# Altium schematic sheet Schematic Prints("All Documents",Physical)
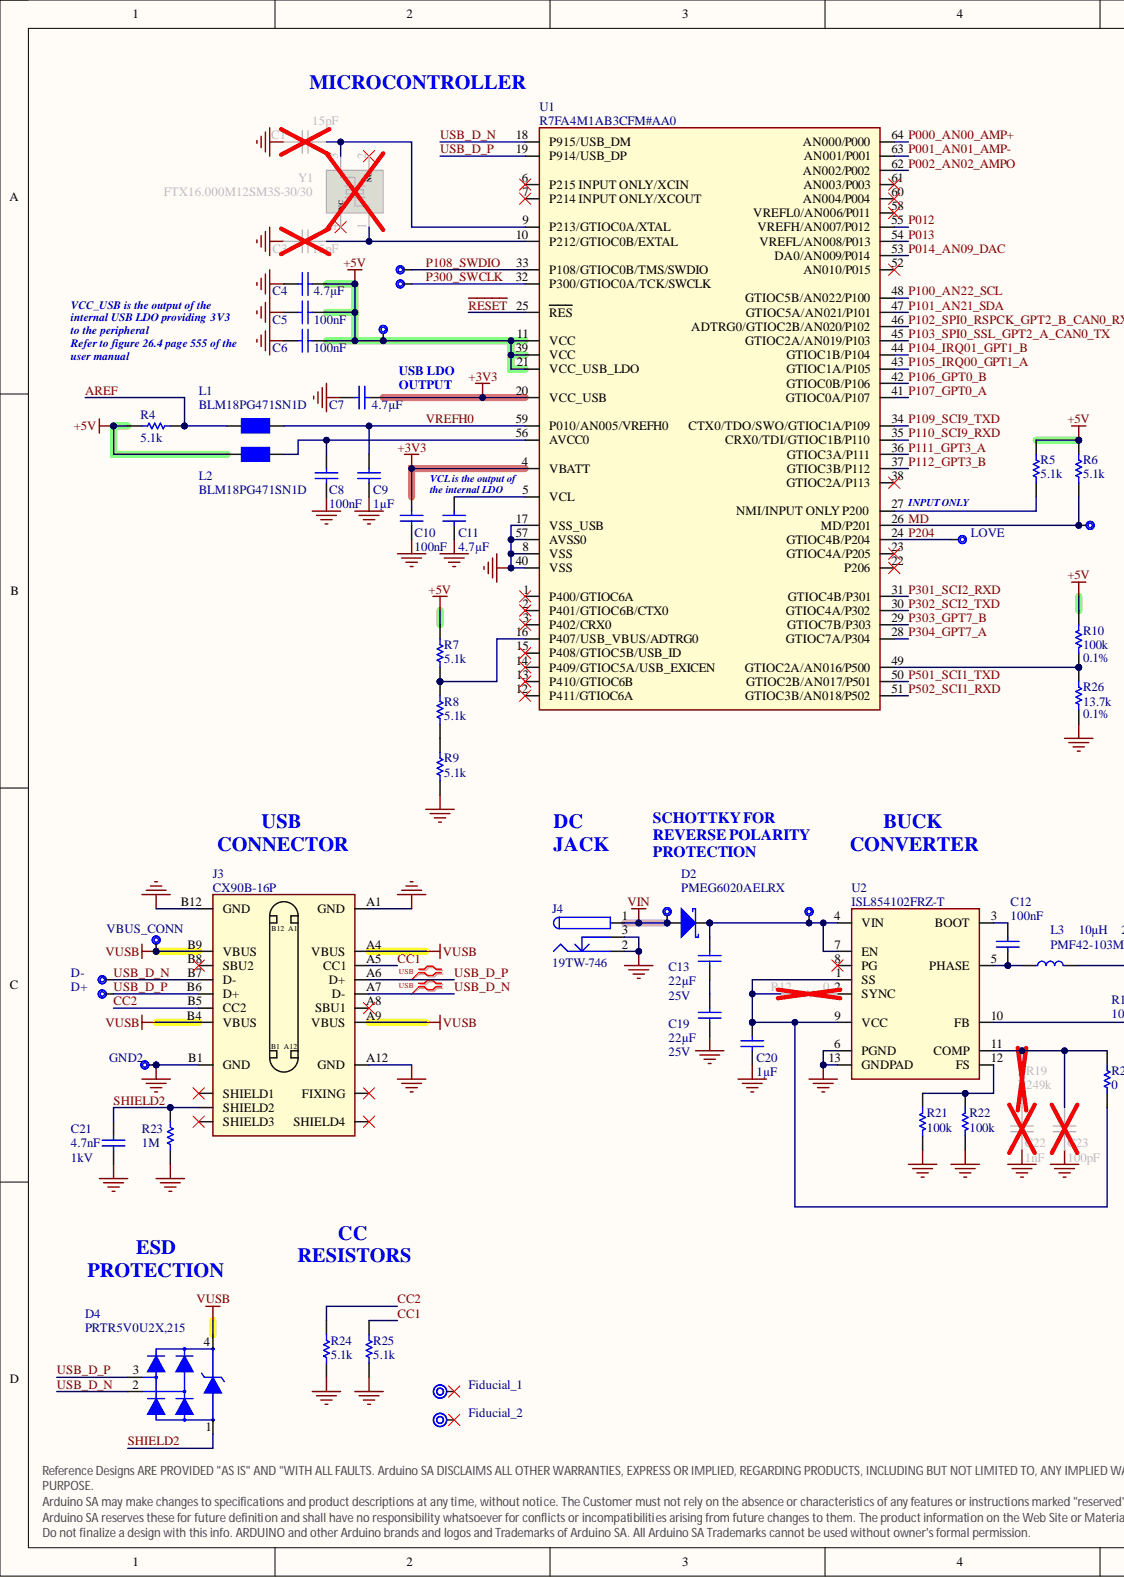
[diagram p0: Schematic Prints("All Documents",Physical), left]
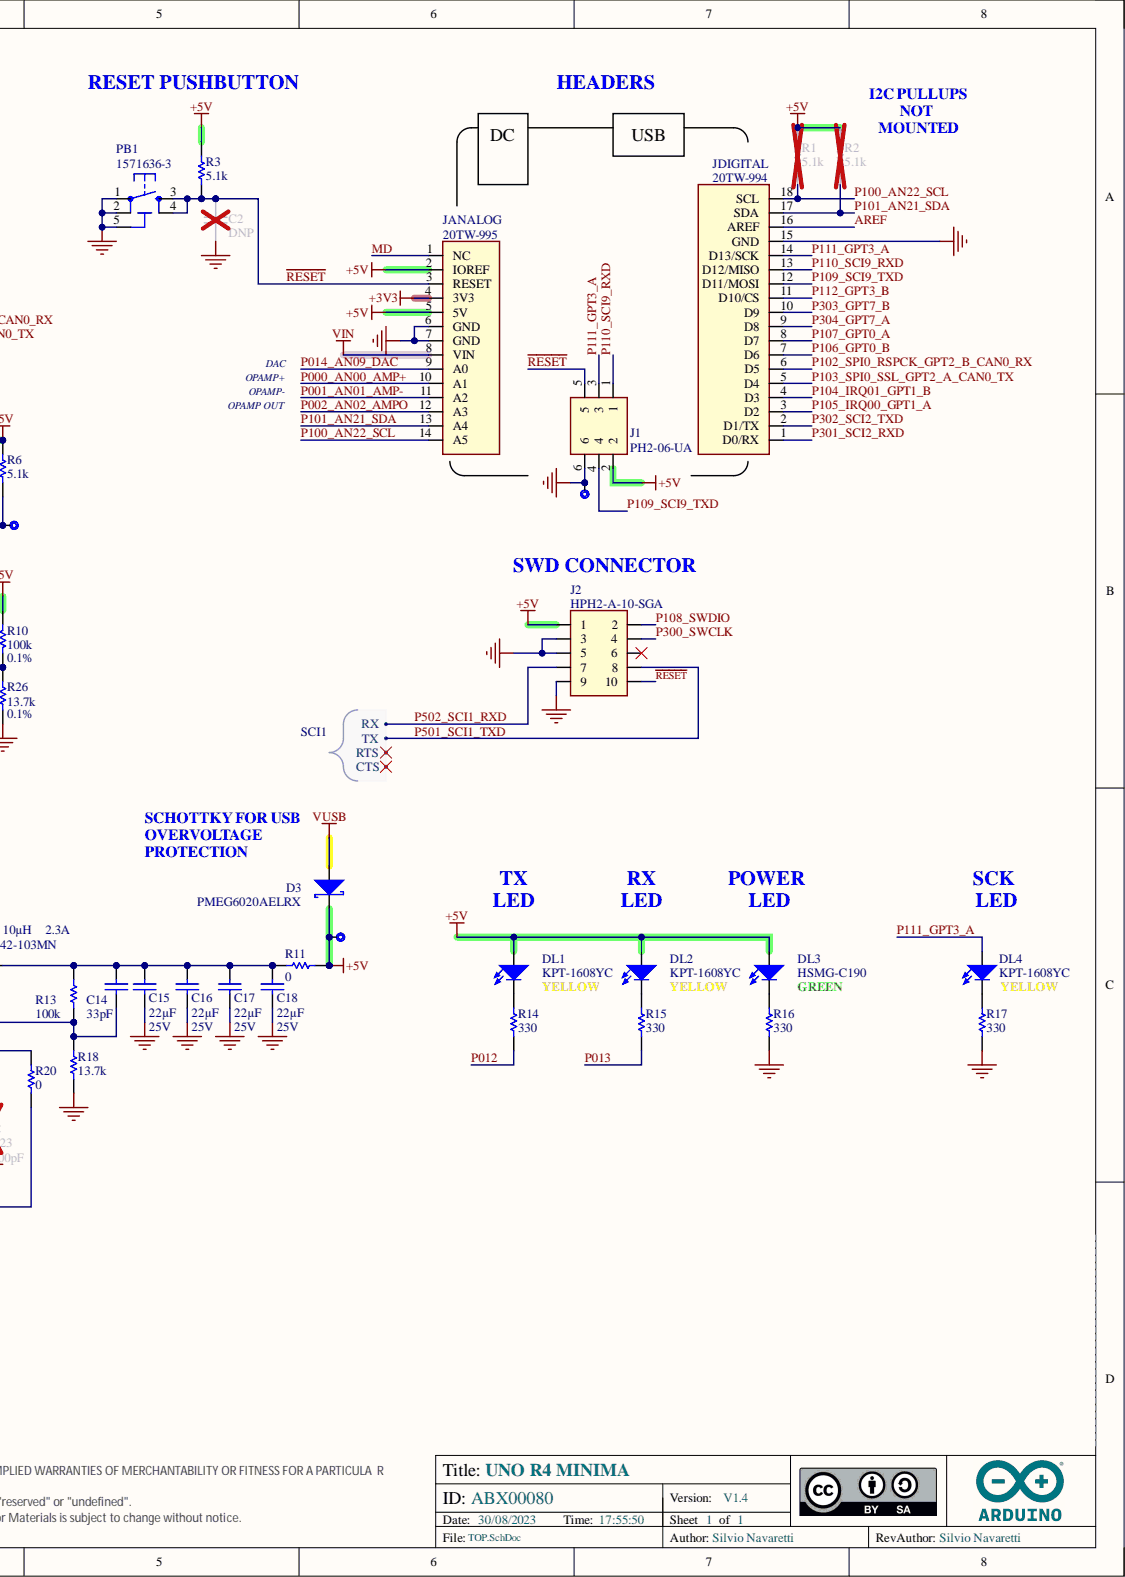
[diagram p1: Schematic Prints("All Documents",Physical), right]

## Parts
1  (no value)
BUCK_IN_TOP1  (no value)
C1  value 15pF
C2  (no value)
C3  value 15pF
C4  value 4.7µF
C5  value 100nF
C6  value 100nF
C7  value 4.7µF
C8  value 100nF
C9  value 1µF
C10  value 100nF
C11  value 4.7µF
C12  value 100nF
C13  value 22µF
C14  value 33pF
C15  value 22µF  Voltage: 25V
C16  value 22µF  Voltage: 25V
C17  value 22µF  Voltage: 25V
C18  value 22µF  Voltage: 25V
C19  value 22µF  Voltage: 25V
C20  value 1µF
C21  value 4.7nF  Voltage: 1kV
C22  value 1nF
C23  value 100pF
D2  Part Number: PMEG6020AELRX
D3  Part Number: PMEG6020AELRX
D4  Part Number: PRTR5V0U2X,215
D+  (no value)
D-  (no value)
DL1  Part Number: KPT-1608YC
DL2  Part Number: KPT-1608YC
DL3  Part Number: HSMG-C190
DL4  Part Number: KPT-1608YC
Fiducial_1  (no value)
Fiducial_2  (no value)
GND1  (no value)
GND2  (no value)
J1  Part Number: PH2-06-UA
J2  Part Number: HPH2-A-10-SGA
J3  Part Number: CX90B-16P
J4  Part Number: 19TW-746
JANALOG  Part Number: 20TW-995
JDIGITAL  Part Number: 20TW-994
L1  Part Number: BLM18PG471SN1D
L2  Part Number: BLM18PG471SN1D
L3  Inductance: 10µH  Part Number: PMF42-103MN
LOVE  (no value)
MD  (no value)
PB1  Part Number: 1571636-3
R1  value 5.1k
R2  value 5.1k
R3  value 5.1k
R4  value 5.1k
R5  value 5.1k
R6  value 5.1k
R7  value 5.1k
R8  value 5.1k
R9  value 5.1k
R10  value 100k  Tolerance: 0.1%
R11  value 0
R12  value 0
R13  value 100k
R14  value 330
R15  value 330
R16  value 330
R17  value 330
R18  value 13.7k
R19  value 249k
R20  value 0
R21  value 100k
R22  value 100k
R23  value 1M
R24  value 5.1k
R25  value 5.1k
R26  value 13.7k  Tolerance: 0.1%
SWCLK1  (no value)
SWDIO1  (no value)
U1  Part Number: R7FA4M1AB3CFM#AA0
U2  Part Number: ISL854102FRZ-T
VBUS_CONN  (no value)
VCC1  (no value)
VIN_TOP1  (no value)
Y1  Part Number: FTX16.000M12SM3S-30/30

## Nets
+3V3: C7.2 C10.2 JANALOG.4(3V3) U1.4(VBATT) U1.20(VCC_USB)
+5V: 1.X C4.2 C5.2 C6.2 D3.C DL1.A DL2.A DL3.A J1.2 J2.1 JANALOG.2(IOREF) JANALOG.5(5V) L2.1 R1.2 R2.2 R3.2 R4.2 R5.1 R6.1 R7.2 R10.2 R11.2 U1.11(VCC) U1.21(VCC_USB_LDO) U1.39(VCC) VCC1.X
GND: C1.2 C2.2 C3.2 C4.1 C5.1 C6.1 C7.1 C8.1 C9.2 C10.1 C11.1 C15.1 C16.1 C17.1 C18.1 C19.1 C20.2 C21.1 C22.1 C23.1 GND1.X GND2.X J1.6 J2.3 J2.5 J2.9 J3.A1(GND) J3.A12(GND) J3.B1(GND) J3.B12(GND) J4.2 J4.3 JANALOG.6(GND) JANALOG.7(GND) JDIGITAL.15(GND) PB1.1 PB1.2 PB1.5 R9.1 R16.1 R17.1 R18.1 R21.1 R22.1 R23.1 R24.1 R25.1 R26.1 U1.8(VSS) U1.17(VSS_USB) U1.40(VSS) U1.57(AVSS0) U2.6(PGND) U2.13(GNDPAD)
VIN: D2.A J4.1 JANALOG.8(VIN) VIN_TOP1.X
VUSB: D3.A D4.4 J3.A4(VBUS) J3.A9(VBUS) J3.B4(VBUS) J3.B9(VBUS) VBUS_CONN.X
AREF_1: JDIGITAL.16(AREF) L1.1 R4.1  [also labelled AREF]
CC1_1: J3.A5(CC1) R25.2  [also labelled CC1]
CC2_1: J3.B5(CC2) R24.2  [also labelled CC2]
MD_1: JANALOG.1(NC) MD.X R6.2 U1.26(MD/P201)  [also labelled MD]
P000_AN00_AMP+_1: JANALOG.10(A1) U1.64(AN000/P000)  [also labelled P000_AN00_AMP+]
P001_AN01_AMP-_1: JANALOG.11(A2) U1.63(AN001/P001)  [also labelled P001_AN01_AMP-]
P002_AN02_AMPO_1: JANALOG.12(A3) U1.62(AN002/P002)  [also labelled P002_AN02_AMPO]
P012_1: R14.1 U1.55(VREFH/AN007/P012)  [also labelled P012]
P013_1: R15.1 U1.54(VREFL/AN008/P013)  [also labelled P013]
P014_AN09_DAC_1: JANALOG.9(A0) U1.53(DA0/AN009/P014)  [also labelled P014_AN09_DAC]
P100_AN22_SCL_1: JANALOG.14(A5) JDIGITAL.18(SCL) R1.1 U1.48(GTIOC5B/AN022/P100)  [also labelled P100_AN22_SCL]
P101_AN21_SDA_1: JANALOG.13(A4) JDIGITAL.17(SDA) R2.1 U1.47(GTIOC5A/AN021/P101)  [also labelled P101_AN21_SDA]
P102_SPI0_RSPCK_GPT2_B_CAN0_RX_1: JDIGITAL.6(D5) U1.46(ADTRG0/GTIOC2B/AN020/P102)  [also labelled P102_SPI0_RSPCK_GPT2_B_CAN0_RX]
P103_SPI0_SSL_GPT2_A_CAN0_TX_1: JDIGITAL.5(D4) U1.45(GTIOC2A/AN019/P103)  [also labelled P103_SPI0_SSL_GPT2_A_CAN0_TX]
P104_IRQ01_GPT1_B_1: JDIGITAL.4(D3) U1.44(GTIOC1B/P104)  [also labelled P104_IRQ01_GPT1_B]
P105_IRQ00_GPT1_A_1: JDIGITAL.3(D2) U1.43(GTIOC1A/P105)  [also labelled P105_IRQ00_GPT1_A]
P106_GPT0_B_1: JDIGITAL.7(D6) U1.42(GTIOC0B/P106)  [also labelled P106_GPT0_B]
P107_GPT0_A_1: JDIGITAL.8(D7) U1.41(GTIOC0A/P107)  [also labelled P107_GPT0_A]
P108_SWDIO_1: J2.2 SWDIO1.X U1.33(P108/GTIOC0B/TMS/SWDIO)  [also labelled P108_SWDIO]
P109_SCI9_TXD_1: J1.4 JDIGITAL.12(D11/MOSI) U1.34(CTX0/TDO/SWO/GTIOC1A/P109)  [also labelled P109_SCI9_TXD]
P110_SCI9_RXD_1: J1.1 JDIGITAL.13(D12/MISO) U1.35(CRX0/TDI/GTIOC1B/P110)  [also labelled P110_SCI9_RXD]
P111_GPT3_A_1: DL4.A J1.3 JDIGITAL.14(D13/SCK) U1.36(GTIOC3A/P111)  [also labelled P111_GPT3_A]
P112_GPT3_B_1: JDIGITAL.11(D10/CS) U1.37(GTIOC3B/P112)  [also labelled P112_GPT3_B]
P204_1: LOVE.X U1.24(GTIOC4B/P204)  [also labelled P204]
P300_SWCLK_1: J2.4 SWCLK1.X U1.32(P300/GTIOC0A/TCK/SWCLK)  [also labelled P300_SWCLK]
P301_SCI2_RXD_1: JDIGITAL.1(D0/RX) U1.31(GTIOC4B/P301)  [also labelled P301_SCI2_RXD]
P302_SCI2_TXD_1: JDIGITAL.2(D1/TX) U1.30(GTIOC4A/P302)  [also labelled P302_SCI2_TXD]
P303_GPT7_B_1: JDIGITAL.10(D9) U1.29(GTIOC7B/P303)  [also labelled P303_GPT7_B]
P304_GPT7_A_1: JDIGITAL.9(D8) U1.28(GTIOC7A/P304)  [also labelled P304_GPT7_A]
P501_SCI1_TXD_1: J2.8 U1.50(GTIOC2B/AN017/P501)  [also labelled P501_SCI1_TXD]
P502_SCI1_RXD_1: J2.7 U1.51(GTIOC3B/AN018/P502)  [also labelled P502_SCI1_RXD]
~{RESET}_1: C2.1 J1.5 J2.10 JANALOG.3(RESET) PB1.3 PB1.4 R3.1 U1.25(RES)  [also labelled ~{RESET}]
SHIELD2_1: C21.2 D4.1 J3.SHIELD2 R23.2  [also labelled SHIELD2]
USB_D_1_N: D4.2 D-.X J3.A7(D-) J3.B7(D-) U1.18(P915/USB_DM)  [also labelled USB_D_N]
USB_D_1_P: D4.3 D+.X J3.A6(D+) J3.B6(D+) U1.19(P914/USB_DP)  [also labelled USB_D_P]
VREFH0_1: C9.1 L1.2 U1.59(P010/AN005/VREFH0)  [also labelled VREFH0]
NetBUCK_IN_TOP1_X: BUCK_IN_TOP1.X C13.2 D2.C U2.4(VIN) U2.7(EN)
NetC1_1: C1.1 U1.9(P213/GTIOC0A/XTAL) Y1.3
NetC3_1: C3.1 U1.10(P212/GTIOC0B/EXTAL) Y1.1
NetC8_2: C8.2 L2.2 U1.56(AVCC0)
NetC11_2: C11.2 U1.5(VCL)
NetC12_1: C12.1 L3.1 U2.5(PHASE)
NetC12_2: C12.2 U2.3(BOOT)
NetC13_1: C13.1 C19.2
NetC14_1: C14.1 R13.2 R18.2 U2.10(FB)
NetC14_2: C14.2 C15.2 C16.2 C17.2 C18.2 L3.2 R11.1 R13.1
NetC20_1: C20.1 R12.2 R20.2 U2.1(SS) U2.9(VCC)
NetC22_2: C22.2 R19.1
NetC23_2: C23.2 R19.2 R20.1 U2.11(COMP)
NetDL1_C: DL1.C R14.2
NetDL2_C: DL2.C R15.2
NetDL3_C: DL3.C R16.2
NetDL4_C: DL4.C R17.2
NetFiducial_1_1: Fiducial_1.1
NetFiducial_2_1: Fiducial_2.1
NetJ2_6: J2.6
NetJ3_A8: J3.A8(SBU1)
NetJ3_B8: J3.B8(SBU2)
NetJ3_FIXING: J3.FIXING
NetJ3_SHIELD1: J3.SHIELD1
NetJ3_SHIELD3: J3.SHIELD3
NetJ3_SHIELD4: J3.SHIELD4
NetR5_2: R5.2 U1.27(NMI/INPUT_ONLY_P200)
NetR7_1: R7.1 R8.2 U1.16(P407/USB_VBUS/ADTRG0)
NetR8_1: R8.1 R9.2
NetR10_1: R10.1 R26.2 U1.49(GTIOC2A/AN016/P500)
NetR12_1: R12.1 U2.2(SYNC)
NetR21_2: R21.2 R22.2 U2.12(FS)
NetU1_1: U1.1(P400/GTIOC6A)
NetU1_2: U1.2(P401/GTIOC6B/CTX0)
NetU1_3: U1.3(P402/CRX0)
NetU1_6: U1.6(P215_INPUT_ONLY/XCIN)
NetU1_7: U1.7(P214_INPUT_ONLY/XCOUT)
NetU1_12: U1.12(P411/GTIOC6A)
NetU1_13: U1.13(P410/GTIOC6B)
NetU1_14: U1.14(P409/GTIOC5A/USB_EXICEN)
NetU1_15: U1.15(P408/GTIOC5B/USB_ID)
NetU1_22: U1.22(P206)
NetU1_23: U1.23(GTIOC4A/P205)
NetU1_38: U1.38(GTIOC2A/P113)
NetU1_52: U1.52(AN010/P015)
NetU1_58: U1.58(VREFL0/AN006/P011)
NetU1_60: U1.60(AN004/P004)
NetU1_61: U1.61(AN003/P003)
NetU2_8: U2.8(PG)
NetY1_2: Y1.2(NC)
NetY1_4: Y1.4(NC)

## Library data of the parts
1 BUCK_IN_TOP1 VIN_TOP1 = (no symbol name): Test point 0.8mm
C1 C3 = (no symbol name): CAP CHIP 0402 15pF 5% 50V C0G; Manufacturer: KEMET; Part Number: C0402C150J5GACTU; Case Code (Imperial): 0402; Dielectric: C0G; Technology: Ceramic; Tolerance: 5%; Voltage: 50V
C2 = (no symbol name): DNP; Package: 0402; Part Number: DNP
C4 C7 C11 = (no symbol name): CAP CHIP 0603 4.7uF 10% 16V X5R; Manufacturer: Murata; Part Number: GRM188R61C475KAAJD; Case Code (Imperial): 0603; Dielectric: X5R; Technology: Ceramic; Tolerance: 10%; Voltage: 16V
C5 C6 C8 C10 C12 = (no symbol name): CAP CHIP 0402 100nF 10% 50V X5R; Manufacturer: Murata; Part Number: GRM155R61H104KE19D; Case Code (Imperial): 0402; Dielectric: X5R; Technology: Ceramic; Tolerance: 10%; Voltage: 50V
C9 C20 = (no symbol name): CAP CHIP 0402 1uF 10% 10V X5R; Manufacturer: Samsung Electro-Mechanics; Part Number: CL05A105KP5NNNC; Case Code (Imperial): 0402; Dielectric: X5R; Technology: Ceramic; Tolerance: 10%; Voltage: 10V
C13 C15-C19 = (no symbol name): CAP CHIP 0805 22uF 20% 25V X5R; Manufacturer: Samsung Electro-Mechanics; Part Number: CL21A226MAQNNNE; Case Code (Imperial): 0805; Dielectric: X5R; Technology: Ceramic; Tolerance: 20%
  C13: Voltage: 25V
C14 = (no symbol name): CAP CHIP 0402 33pF 5% 50V C0G; Manufacturer: Murata; Part Number: GRM1555C1H330JA01D; Case Code (Imperial): 0402; Dielectric: C0G; Technology: Ceramic; Tolerance: 5%; Voltage: 50V
C21 = (no symbol name): CAP CHIP 0805 4.7nF 10% 1000V X7R; Manufacturer: PSA Shenzen; Part Number: FV21X472K102ECG; Case Code (Imperial): 0805; Dielectric: X7R; Technology: Ceramic; Tolerance: 10%
C22 = (no symbol name): CAP CHIP 0402 1nF 10% 50V X7R; Manufacturer: KEMET; Part Number: GRM155R71H102KA01D; Case Code (Imperial): 0402; Dielectric: X7R; Technology: Ceramic; Tolerance: 10%; Voltage: 50V
C23 = (no symbol name): CAP CHIP 0402 100pF 10% 50V C0G; Manufacturer: Yageo; Part Number: CC0402JRNPO9BN101; Tolerance: 5%; Case Code (Imperial): 0402; Dielectric: C0G; Technology: Ceramic; Voltage: 50V
D2 D3 = (no symbol name): DIODE SCHOTTKY SMD 2A 60V SOD123FL; Manucturer Part Number 2: SS26L; Manufacturer: Nexperia; Forward Voltage: 0.6V; Manucturer 2: Hottech; Forward Current: 2A
D4 = (no symbol name): TVS ARRAY 4LIN.8kV 1pF SOT143B; Manufacturer: Nexperia
D+ D- GND1 GND2 LOVE MD SWCLK1 SWDIO1 VBUS_CONN VCC1 = (no symbol name): Test point 1.00mm
DL1 DL2 DL4 = (no symbol name): LED SMD 0603 GIA588   8mcd 120^; Manufacturer: Kingbright
DL3 = (no symbol name): LED SMD 0603 GREEN/568 15mcd 120^; Manufacturer: Broadcom
Fiducial_1 Fiducial_2 = (no symbol name): Fiducial mark 1mm with 2mm solder
J1 = (no symbol name): CONN Header Through Hole 6 position 0.100" (2.54mm); Manufacturer: Adam Tech
J2 = (no symbol name): CONN CONN STRIP THD 1,27 M/2/D 10v AuF H6,3 JTAG; Manufacturer: Adam Tech
J3 = (no symbol name): CONN RCPT USB3.1 TYPEC 16POS SMD; Manufacturer: Hirose
J4 = (no symbol name): CONN PWR JACK 2.1X5.5MM SOLDER; Manufacturer: 4UCON
JANALOG = (no symbol name): CONN STRIP F/1/D 14v SER.NERA s1pin; Manufacturer: 4UCONN
JDIGITAL = (no symbol name): CONN STRIP F/1/D 18v SER.NERA s1pin; Manufacturer: 4UCONN
L1 L2 = (no symbol name): FERRITE SMD 0603 470R 1A 0.2R; Manufacturer: Murata; DC Resistance (DCR): 200mR; Tolerance: 25%; Case Code (Imperial): 0603; Max DC Current: 1A; Max Operating Temperature: 125°C; Min Operating Temperature: -55°C
L3 = (no symbol name): IND 10uH 20%, max 235mR, IDC 2.3A, Isat 2.3A, shielded, max 5MHz, 4.5x4.5x1.8mm; Manufacturer: K-WELL; DC Resistance (DCR): 235m; Max DC Current: 2.3A; Tolerance: 20%
PB1 = (no symbol name): PUSHBUTTON SMD 6x6 5 PINS; Manufacturer: TE Connectivity
R1 R2 = (no symbol name): RES CHIP 0603 5k1 1% 1/10W; Manufacturer: Bourns; Part Number: CR0603AFX-5101EAS; Power: 100mW; Temperature coefficient: 100ppm; Case Code (Imperial): 0603; Technology: Thick film; Tolerance: 1%
R3-R9 R24 R25 = (no symbol name): RES CHIP 0402 5k1 1% 1/16W; Manufacturer: YAGEO; Part Number: RC0402FR-075K1L; Power: 63mW; Temperature coefficient: 100ppm; Case Code (Imperial): 0402; Technology: Thick film; Tolerance: 1%
R10 = (no symbol name): RES CHIP 0402 100k 0.1% 100ppm 1/16W; Manufacturer: Walsin; Part Number: WF04H1003BT; Power: 62.5mW; Case Code (Imperial): 0402; Technology: Thick film; Temperature coefficient: 100ppm
R11 R12 R20 = (no symbol name): RES CHIP 0402 0R 1/16W; Manufacturer: Vishay; Part Number: CRCW04020000Z0EDC; Power: 62.5mW; Temperature coefficient: 0ppm; Tolerance: 0%; Case Code (Imperial): 0402; Technology: Thick film
R13 R21 R22 = (no symbol name): RES CHIP 0402 100k 1% 1/16W; Manufacturer: Vishay; Part Number: CRCW0402100KFKEDC; Power: 62.5mW; Temperature coefficient: 100ppm; Case Code (Imperial): 0402; Technology: Thick film; Tolerance: 1%
R14-R17 = (no symbol name): RES CHIP 0402 330R 5% 1/16W; Manufacturer: Vishay; Part Number: CRCW0402330RJNED; Power: 62.5mW; Temperature coefficient: 200ppm; Case Code (Imperial): 0402; Technology: Thick film; Tolerance: 5%
R18 = (no symbol name): RES CHIP 0402 13k7 1% 1/16W; Manufacturer: YAGEO; Part Number: RC0402FR-0713K7L; Power: 62.5mW; Temperature coefficient: 100ppm; Case Code (Imperial): 0402; Technology: Thick film; Tolerance: 1%
R19 = (no symbol name): RES CHIP 0402 249k 1% 1/16W; Manufacturer: YAGEO; Part Number: AC0402FR-07249KL; Power: 62.5mW; Temperature coefficient: 100ppm; Case Code (Imperial): 0402; Technology: Thick film; Tolerance: 1%
R23 = (no symbol name): RES CHIP 0402 1M 1% 1/16W; Manufacturer: Vishay; Part Number: CRCW04021M00FKED; Power: 62.5mW; Temperature coefficient: 100ppm; Case Code (Imperial): 0402; Technology: Thick film; Tolerance: 1%
R26 = (no symbol name): RES CHIP 0402 13k7 0.1% 100PPM 1/16W; Manufacturer: Walsin; Part Number: WF04H1372BTL; Power: 62.5mW; Temperature coefficient: 200ppm; Case Code (Imperial): 0402; Technology: Thick film
U1 = (no symbol name): IC uC RA4M1 32b M4 48MHz 254KB flash 32KB RAM 105°C LQFP64 10x10mm; Manufacturer: Renesas
U2 = (no symbol name): IC BUCK Vin 40V Iout 1.2A 2MHz 12DFN 3x4mm; Manufacturer: Renesas
Y1 = (no symbol name): CRYSTAL 16.0000MHz 12pF SMD; Manufacturer: Fronter Electronics
